# Altium schematic sheet Power.SchDoc
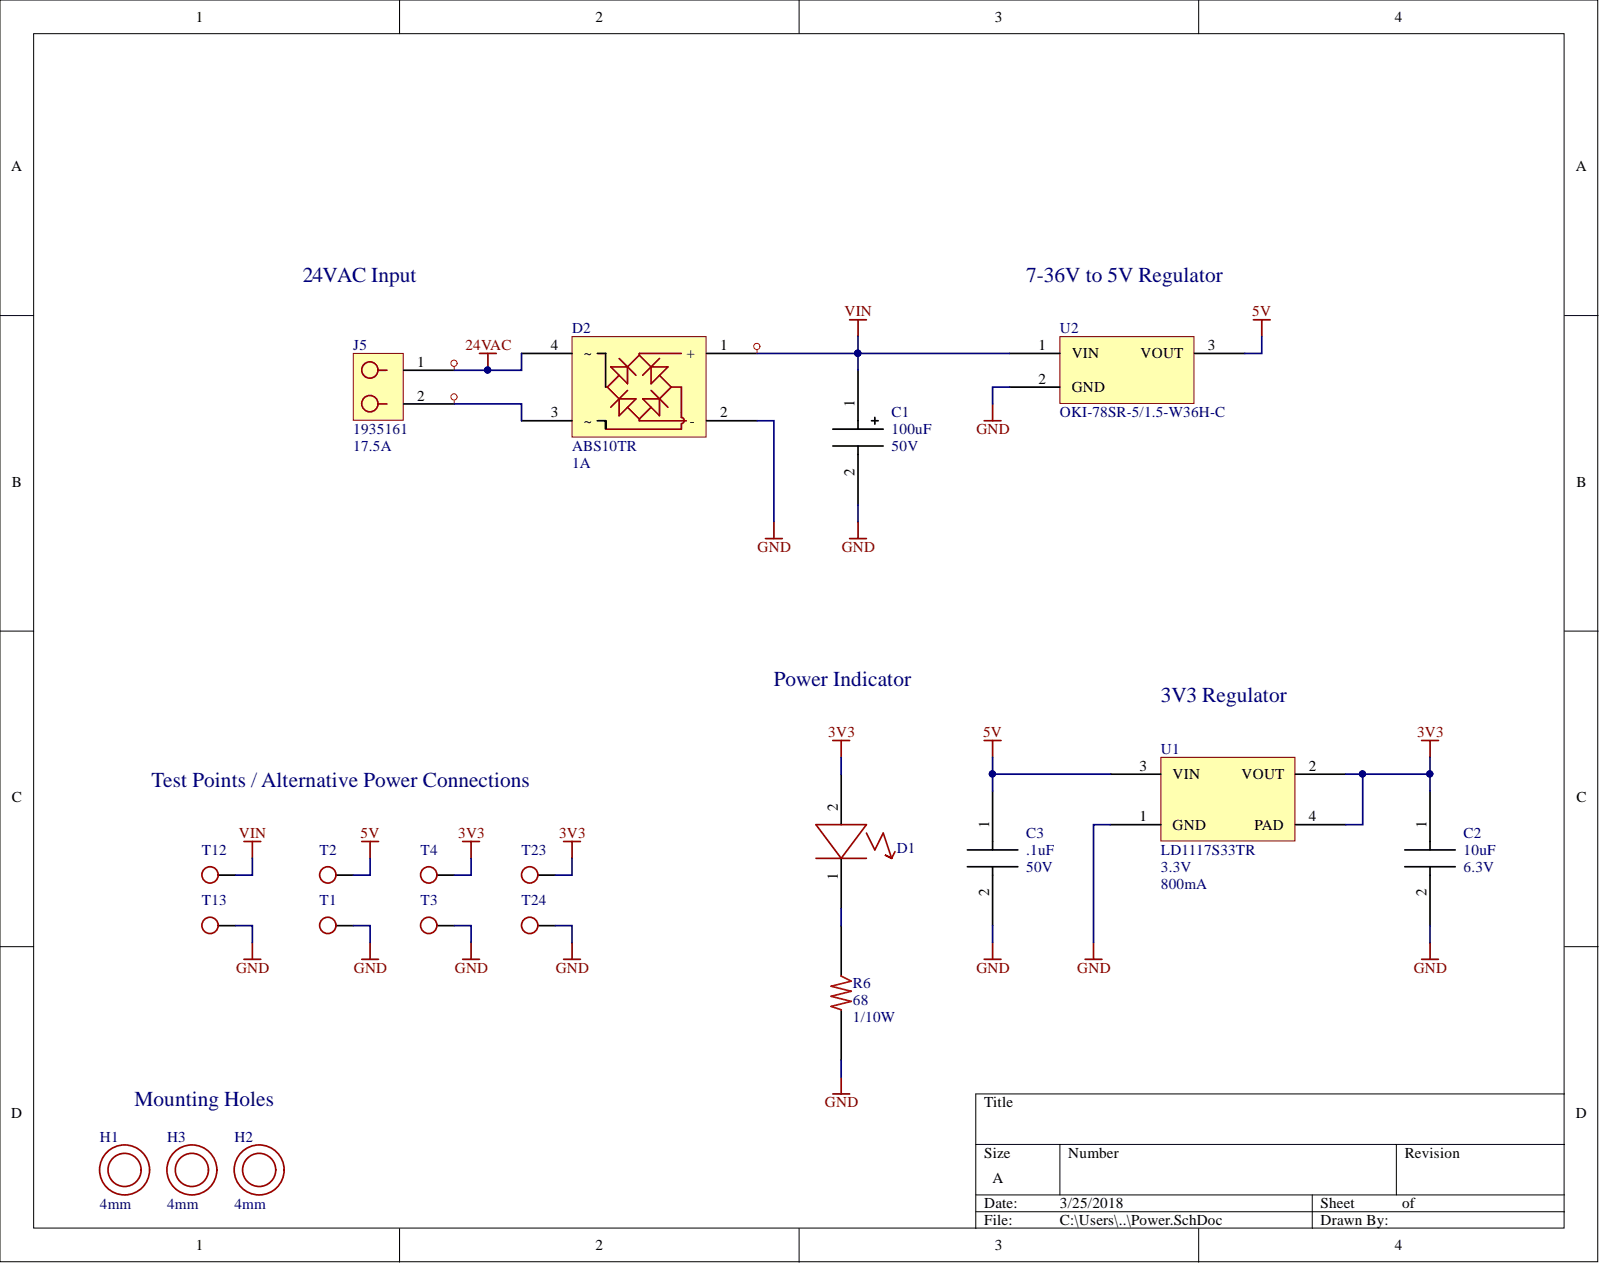
[diagram p0: Power.SchDoc]

## Parts
C1  value 100uF  Rating: 50V  [EEE-1HA101UP]  (hidden comment: CAP)
C2  value 10uF  Rating: 6.3V  [0603]
C3  value .1uF  Rating: 50V  [0603]
D1  D_LED_LED5MM  [LED5MM]  (value not drawn)
D2  PartNumber: ABS10TR  Rating: 1A  [ABS]  (hidden comment: D_RECTBRIDGE_ABS)
H1  value 4mm  [MOUNTHOLE4MM]  (hidden comment: H_MOUNTHOLE_MOUNTHOLE4MM)
H2  value 4mm  [MOUNTHOLE4MM]  (hidden comment: H_MOUNTHOLE_MOUNTHOLE4MM)
H3  value 4mm  [MOUNTHOLE4MM]  (hidden comment: H_MOUNTHOLE_MOUNTHOLE4MM)
J5  Rating: 17.5A  [1935161]  (hidden comment: J_2POSTERM_1935161)
R6  value 68  Rating: 1/10W  [0603]  (hidden comment: R_RES_0603)
T1  TESTPOINT  [TESTPOINT1MM]  (value not drawn)
T2  TESTPOINT  [TESTPOINT1MM]  (value not drawn)
T3  TESTPOINT  [TESTPOINT1MM]  (value not drawn)
T4  TESTPOINT  [TESTPOINT1MM]  (value not drawn)
T12  TESTPOINT  [TESTPOINT1MM]  (value not drawn)
T13  TESTPOINT  [TESTPOINT1MM]  (value not drawn)
T23  TESTPOINT  [TESTPOINT1MM]  (value not drawn)
T24  TESTPOINT  [TESTPOINT1MM]  (value not drawn)
U1  value 3.3V  PartNumber: LD1117S33TR  [SOT223]  (hidden comment: U_LD1117S33TR_SOT223)
U2  U_OKI-78SR-5/1.5-W36H-C_OKI-78SR-5/1.5-W36H-C  [OKI-78SR-5/1.5-W36H-C]  (value not drawn)

## Nets
3V3: C2.1 D1.2 T4.1 T23.1 U1.2(VOUT) U1.4(PAD)
5V: C3.1 T2.1 U1.3(VIN) U2.3
24VAC: D2.4(~) J5.1
GND: C1.2 C2.2 C3.2 D2.2(-) R6.2 T1.1 T3.1 T13.1 T24.1 U1.1(GND) U2.2
VIN: C1.1 D2.1(+) T12.1 U2.1
NetD1_1: D1.1 R6.1
NetD2_3: D2.3(~) J5.2

## Library data of the parts
C1 = C_CAP-P_EEE-1HA101UP: Manufacturer: Panasonic Electronic Components; Component Kind: Standard; Library Name: Hub.SchLib; PartNumber: EEE-1HA101UP
C2 = C_CAP_0603: Manufacturer: Taiyo Yuden; PartNumber: JMK107ABJ106MA-T; Component Kind: Standard
C3 = C_CAP_0603: Manufacturer: KEMET; PartNumber: C0603C104K5RACAUTO; Component Kind: Standard
D2 = D_RECTBRIDGE_ABS: Manufacturer: SMC Diode Solutions; Component Kind: Standard; Library Name: Hub.SchLib
J5 = J_2POSTERM_1935161: Manufacturer: Phoenix Contact; Component Kind: Standard; Library Name: Hub.SchLib; PartNumber: 1935161
R6 = R_RES_0603: Manufacturer: Yageo; PartNumber: RC0603FR-0768RL; Component Kind: Standard; Library Name: SeniorProject.SchLib
T1-T4 T12 T13 T23 T24 = T_TESTPOINT_TESTPOINT1MM: Value: 1mm; Component Kind: Standard; Library Name: Hub.SchLib
U1 = U_LD1117S33TR_SOT223: Manufacturer: STM; Rating: 800mA; Component Kind: Standard; Library Name: Hub.SchLib
U2 = U_OKI-78SR-5/1.5-W36H-C_OKI-78SR-5/1.5-W36H-C: Manufacturer: Murata Power Solutions Inc.; Rating: 1.5A; Component Kind: Standard; Library Name: Hub.SchLib; PartNumber: OKI-78SR-5/1.5-W36H-C
D1 = D_LED_LED5MM: Component Kind: Standard; Library Name: Hub.SchLib
H1-H3 = H_MOUNTHOLE_MOUNTHOLE4MM: Component Kind: Standard; Library Name: Hub.SchLib
